# Altium schematic sheet Schematic2.SchDoc
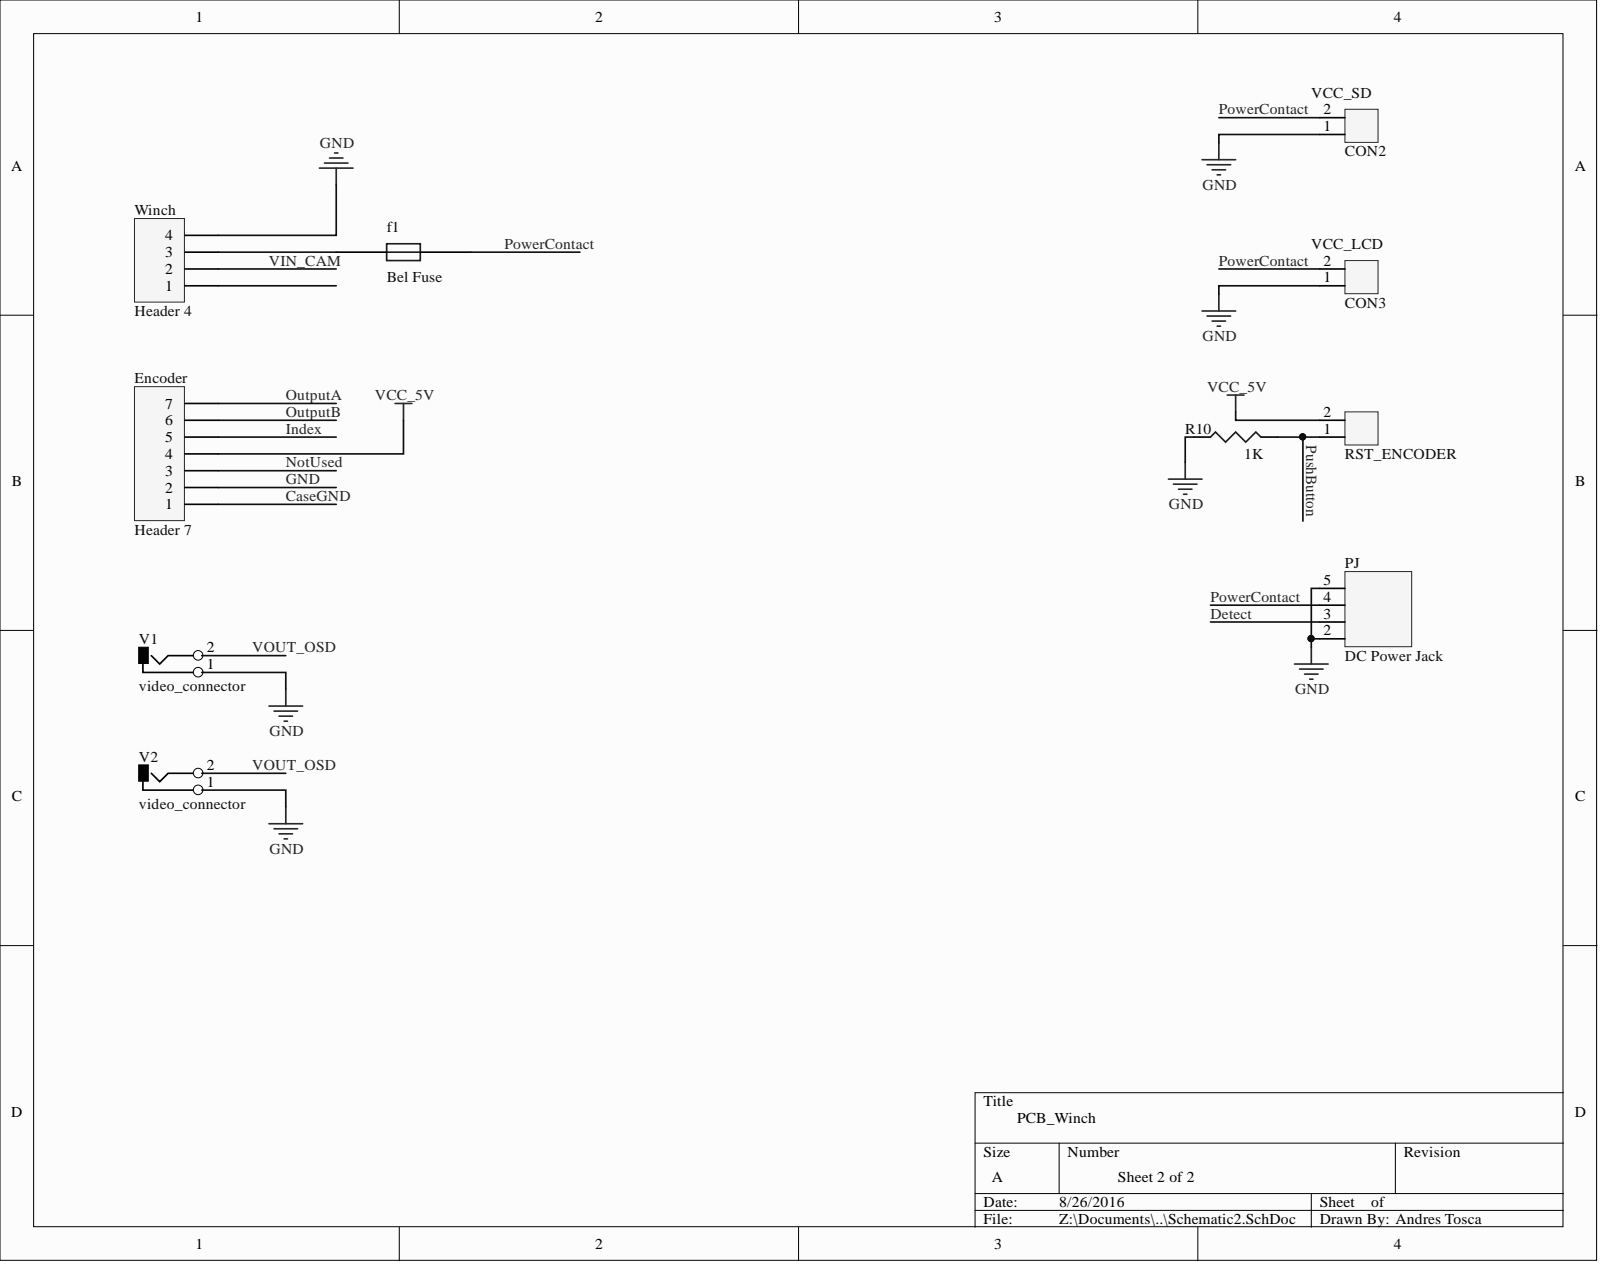
[diagram p0: Schematic2.SchDoc]

## Parts
CON2  VCC_SD  [MOLEX_2CIRCUITS]
CON3  VCC_LCD  [MOLEX_2CIRCUITS]
Encoder  Header 7  [MOLEX_7CIRCUITS]
f1  Bel Fuse  [Bel_Fuse]
PJ  DC Power Jack  [DC Power Jack TE]
R10  value 1K  [RESC2012]  (hidden comment: Res3)
RST_ENCODER  MOLEX_2circuits  [MOLEX_2CIRCUITS]  (value not drawn)
V1  video_connector  [Video Connector]
V2  video_connector  [Video Connector]
Winch  Header 4  [MOLEX_4CIRCUITS]

## Nets
VCC_5V: Encoder.4 RST_ENCODER.2
CASEGND: Encoder.1
DETECT: PJ.3
GND: CON2.1 CON3.1 Encoder.2 PJ.2 PJ.5 R10.1 V1.1 V2.1 Winch.4
INDEX: Encoder.5
NOTUSED: Encoder.3
OUTPUTA: Encoder.7
OUTPUTB: Encoder.6
POWERCONTACT: CON2.2 CON3.2 f1.2 PJ.4
PUSHBUTTON: R10.2 RST_ENCODER.1
VIN_CAM: Winch.2
VOUT_OSD: V1.2 V2.2
Netf1_1: f1.1 Winch.3

Not connected: Winch 1

## Library data of the parts
CON2 CON3 = MOLEX_2circuits: Component Kind: Standard; Library Name: Schlib.SchLib
Encoder = Header 7: Header, 7-Pin; Component Kind: Standard; LatestRevisionDate: 17-Jul-2002; LatestRevisionNote: Re-released for DXP Platform.; Library Name: Miscellaneous Connectors.IntLib; Signal Integrity: Connector; Publisher: Altium Limited
PJ = DC Power Jack TE: Component Kind: Standard; Library Name: Schlib.SchLib
R10 = Res3: Resistor; Component Kind: Standard; LatestRevisionDate: 29-May-2009; LatestRevisionNote: IPC-7351 Footprint Added.; Library Name: Miscellaneous Devices.IntLib; PackageDescription: Chip Resistor; PackageReference: J1-0603; Published: 8-Jun-2000; Signal Integrity: Res; Simulation: RESISTOR; Publisher: Altium Limited
Winch = Header 4: Header, 4-Pin; Component Kind: Standard; LatestRevisionDate: 17-Jul-2002; LatestRevisionNote: Re-released for DXP Platform.; Library Name: Miscellaneous Connectors.IntLib; Signal Integrity: Connector; Publisher: Altium Limited
f1 = Bel Fuse: Component Kind: Standard; Library Name: Schlib.SchLib
RST_ENCODER = MOLEX_2circuits: Component Kind: Standard; Library Name: Schlib.SchLib
V1 V2 = video_connector: Component Kind: Standard; Library Name: Schlib.SchLib
